# Altium schematic sheet S3Remote4R3_V2.SchDoc
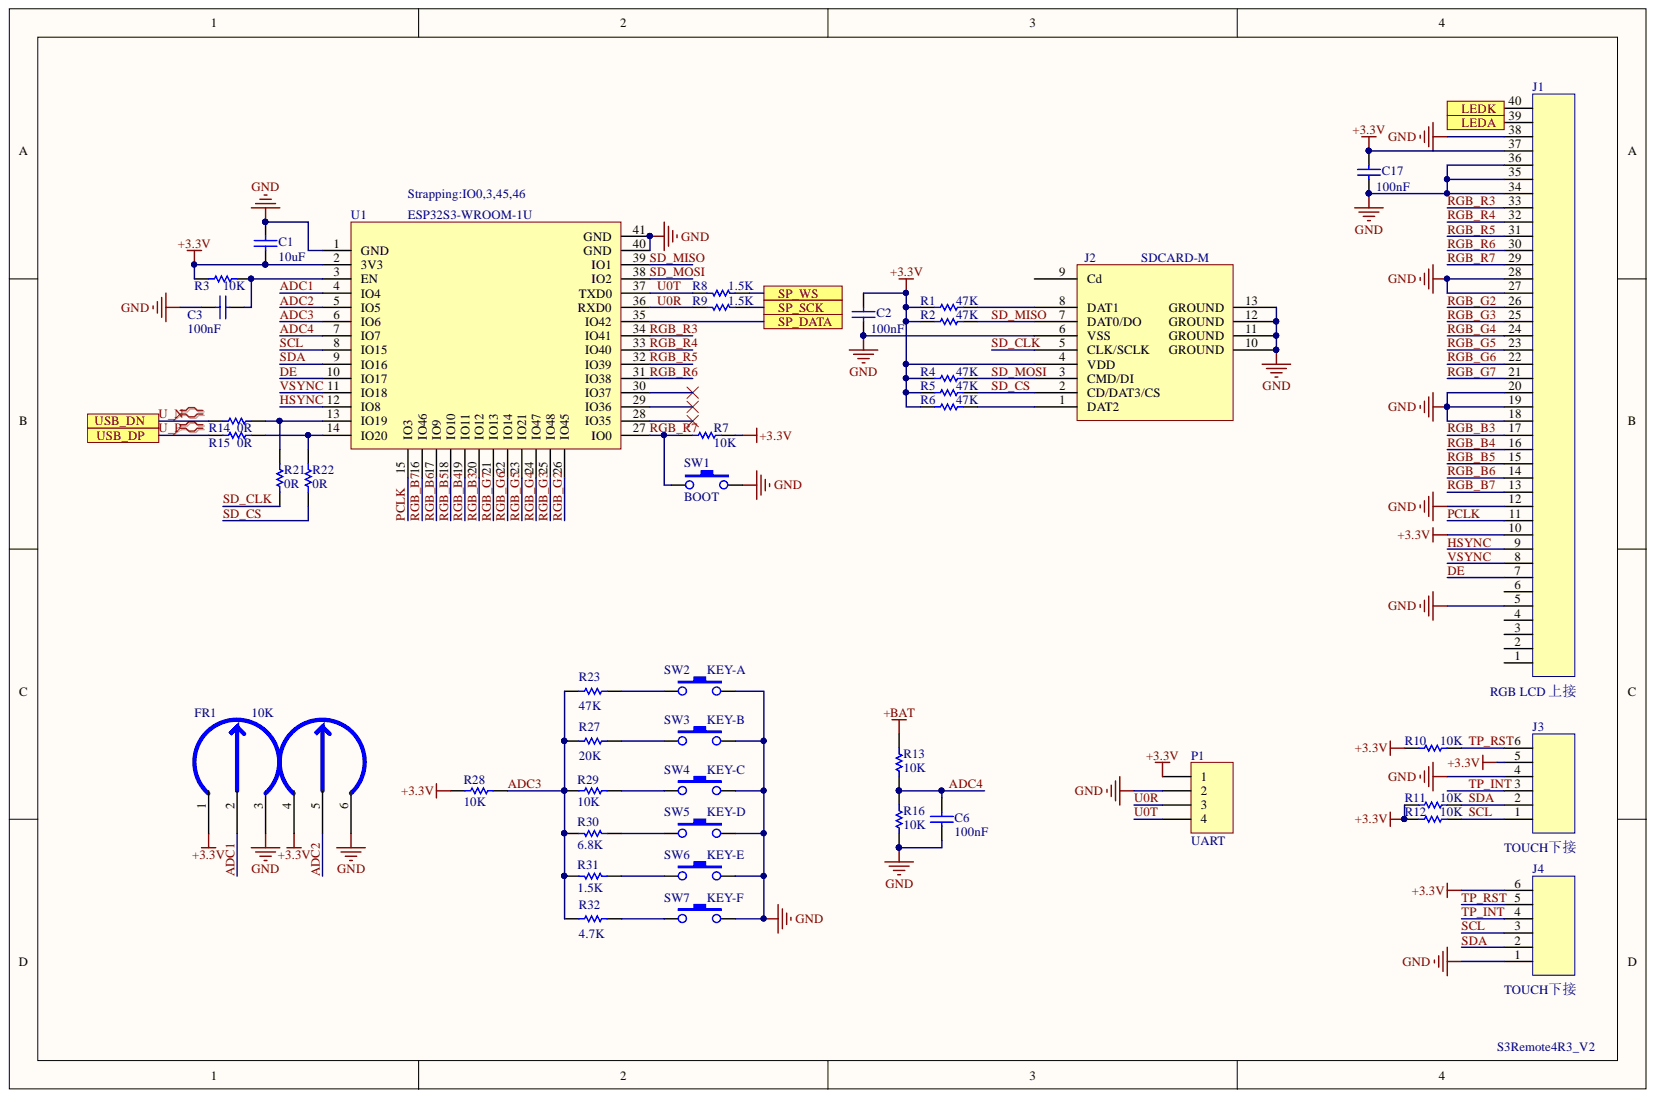
[diagram p0: S3Remote4R3_V2.SchDoc]

## Parts
C1  10uF  [C0603B]
C2  100nF  [C0603B]
C3  100nF  [C0603B]
C6  100nF  [C0603B]
C17  100nF  [C0603B]
FR1  10K  [FJ08K-4]
J1  RGB LCD 上接  [FPC0.5-40P]
J2  SDCARD-M  [SDCARD-M]
J3  TOUCH下接  [FPC0.5-6P]
J4  TOUCH下接  [FPC0.5-6P]
P1  UART  [HDR1X4]
R1  47K  [RES0603]
R2  47K  [RES0603]
R3  10K  [RES0603]
R4  47K  [RES0603]
R5  47K  [RES0603]
R6  47K  [RES0603]
R7  10K  [RES0603]
R8  1.5K  [RES0603]
R9  1.5K  [RES0603]
R10  10K  [RES0603]
R11  10K  [RES0603]
R12  10K  [RES0603]
R13  10K  [RES0603]
R14  0R  [RES0603]
R15  0R  [RES0603]
R16  10K  [RES0603]
R21  0R  [RES0603]
R22  0R  [RES0603]
R23  47K  [RES0603]
R27  20K  [RES0603]
R28  10K  [RES0603]
R29  10K  [RES0603]
R30  6.8K  [RES0603]
R31  1.5K  [RES0603]
R32  4.7K  [RES0603]
SW1  BOOT  [SW-4x3]
SW2  KEY-A  [muteButton6x6]
SW3  KEY-B  [muteButton6x6]
SW4  KEY-C  [muteButton6x6]
SW5  KEY-D  [muteButton6x6]
SW6  KEY-E  [muteButton6x6]
SW7  KEY-F  [muteButton6x6]
U1  ESP32S3-WROOM-1U  [ESP32-S3-WROOM-1]

## Ports
LEDA, LEDK, SP_DATA, SP_SCK, SP_WS, USB_DN, USB_DP

## Nets
+3.3V: C1.1 C2.2 C17.2 FR1.1 FR1.4 J1.10 J1.37 J2.4(VDD) J3.5 J4.6 P1.1 R1.2 R2.2 R3.2 R4.2 R5.2 R6.2 R7.2 R10.1 R11.1 R12.1 R28.1 U1.2(3V3)
+BAT: R13.1
GND: C1.2 C2.1 C3.1 C6.1 C17.1 FR1.3 FR1.6 J1.5 J1.12 J1.18 J1.19 J1.20 J1.27 J1.28 J1.34 J1.35 J1.36 J1.38 J2.6(VSS) J2.10(GROUND) J2.11(GROUND) J2.12(GROUND) J2.13(GROUND) J3.4 J4.1 P1.2 R16.2 SW1.2 SW2.2 SW3.2 SW4.2 SW5.2 SW6.2 SW7.2 U1.1(GND) U1.40(GND) U1.41(GND)
ADC1: FR1.2 U1.4(IO4)
ADC2: FR1.5 U1.5(IO5)
ADC3: R23.2 R27.2 R28.2 R29.1 R30.2 R31.2 R32.2 U1.6(IO6)
ADC4: C6.2 R13.2 R16.1 U1.7(IO7)
DE: J1.7 U1.10(IO17)
HSYNC: J1.9 U1.12(IO8)
LEDA: J1.39 ; port LEDA
LEDK: J1.40 ; port LEDK
PCLK: J1.11 U1.15(IO3)
RGB_B3: J1.17 U1.20(IO12)
RGB_B4: J1.16 U1.19(IO11)
RGB_B5: J1.15 U1.18(IO10)
RGB_B6: J1.14 U1.17(IO9)
RGB_B7: J1.13 U1.16(IO46)
RGB_G2: J1.26 U1.26(IO45)
RGB_G3: J1.25 U1.25(IO48)
RGB_G4: J1.24 U1.24(IO47)
RGB_G5: J1.23 U1.23(IO21)
RGB_G6: J1.22 U1.22(IO14)
RGB_G7: J1.21 U1.21(IO13)
RGB_R3: J1.33 U1.34(IO41)
RGB_R4: J1.32 U1.33(IO40)
RGB_R5: J1.31 U1.32(IO39)
RGB_R6: J1.30 U1.31(IO38)
RGB_R7: J1.29 R7.1 SW1.1 U1.27(IO0)
SCL: J3.1 J4.3 R12.2 U1.8(IO15)
SD_CLK: J2.5(CLK/SCLK) R21.2
SD_CS: J2.2(CD/DAT3/CS) R5.1 R22.2
SD_MISO: J2.7(DAT0/DO) R2.1 U1.39(IO1)
SD_MOSI: J2.3(CMD/DI) R4.1 U1.38(IO2)
SDA: J3.2 J4.2 R11.2 U1.9(IO16)
SP_DATA: U1.35(IO42) ; port SP_DATA
SP_SCK: R9.1 ; port SP_SCK
SP_WS: R8.1 ; port SP_WS
TP_INT: J3.3 J4.4
TP_RST: J3.6 J4.5 R10.2
U0R: P1.3 R9.2 U1.36(RXD0)
U0T: P1.4 R8.2 U1.37(TXD0)
U_N: R14.2 ; port USB_DN
U_P: R15.2 ; port USB_DP
VSYNC: J1.8 U1.11(IO18)
NetC3_2: C3.2 R3.1 U1.3(EN)
NetJ2_1: J2.1(DAT2) R6.1
NetJ2_8: J2.8(DAT1) R1.1
NetR14_1: R14.1 R21.1 U1.13(IO19)
NetR15_1: R15.1 R22.1 U1.14(IO20)
NetR23_1: R23.1 SW2.1
NetR27_1: R27.1 SW3.1
NetR29_2: R29.2 SW4.1
NetR30_1: R30.1 SW5.1
NetR31_1: R31.1 SW6.1
NetR32_1: R32.1 SW7.1

Not connected: J1 1 2 3 4 6; J2 9(Cd); U1 28(IO35) 29(IO36) 30(IO37)

## Library data of the parts
C1-C3 C6 C17 = CAP-Nonpolarity: CAP; Component Kind: Standard; Library Name: Johan.IntLib
FR1 = Potentiometer-FJ08K_2: 摇杆; Component Kind: Standard; Library Name: Johan.IntLib
J1 = FPC0.5-40P: 贴片; Component Kind: Standard; Library Name: FPC.IntLib
J2 = CONN-SDCARD-M: TF卡槽; Component Kind: Standard; Library Name: Johan.IntLib
J3 J4 = FPC0.5-6P: 贴片; Component Kind: Standard; Library Name: FPC.IntLib
P1 = Header 4: Header, 4-Pin; Component Kind: Standard; LatestRevisionDate: 17-Jul-2002; LatestRevisionNote: Re-released for DXP Platform.; Library Name: Miscellaneous Connectors.IntLib; Publisher: Altium Limited
R1-R9 R13-R16 R21-R23 R27-R32 = RES: Component Kind: Standard; Description: RES; Library Name: Johan.IntLib
R10-R12 = RES: 电阻; Component Kind: Standard; Library Name: Johan.IntLib
SW1-SW7 = SW-2P: 按键; Component Kind: Standard; Library Name: Johan.IntLib
U1 = MODULAR-ESP32S3-WROOM: 模块ESP32S3-WROOM; Component Kind: Standard; Library Name: Johan.IntLib
